# Altium schematic sheet Hub.SchDoc
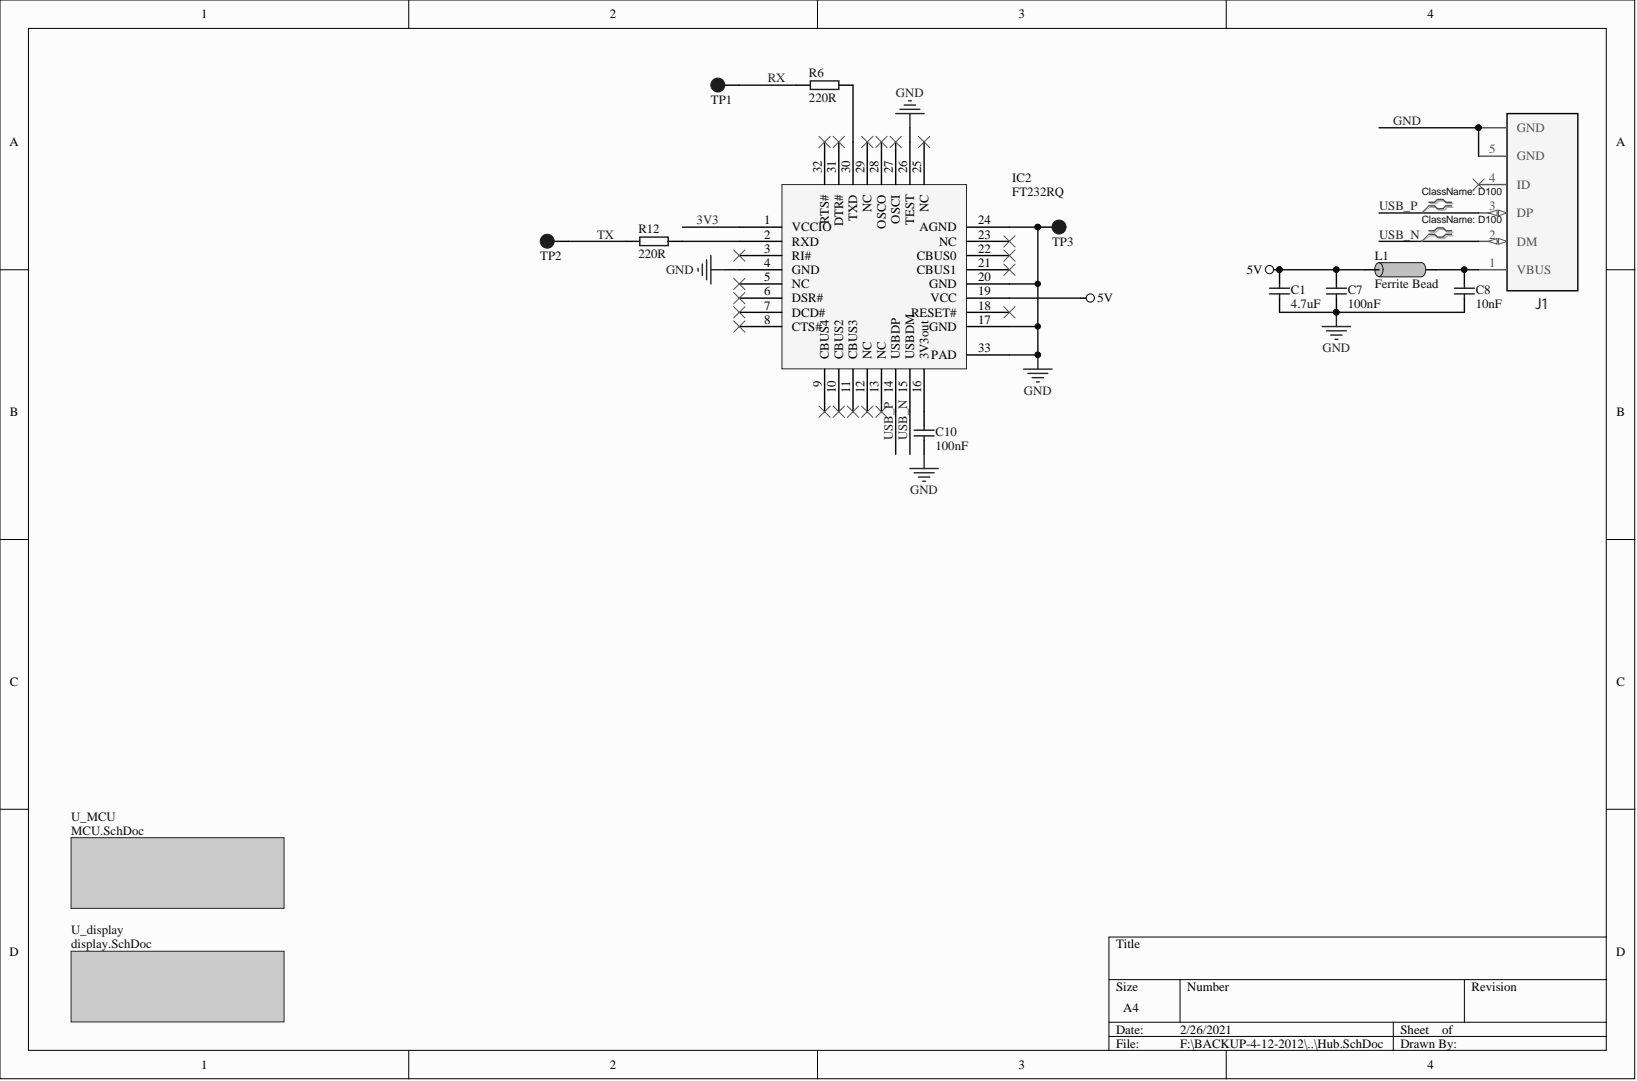
[diagram p0: Hub.SchDoc]

## Parts
C1  value 4.7uF  [CAPC1608N]  (hidden comment: CAP-NP)
C7  value 100nF  [CC0402-min-ipc]  (hidden comment: CAP-NP)
C8  value 10nF  [CC0402-min-ipc]  (hidden comment: CAP-NP)
C10  value 100nF  [CC0402-min-ipc]  (hidden comment: CAP-NP)
IC2  FT232RQ  [FT232RQ]
J1  CON_USB_micro_AB  [CON_USB_micro_AB]  (value not drawn)
L1  value Ferrite Bead  [CAPC1608N]  (hidden comment: INDUCTOR-0603)
R6  value 220R  [CC0402-min-ipc]  (hidden comment: RES)
R12  value 220R  [CC0402-min-ipc]  (hidden comment: RES)
TP1  TP  [TPSMD1mm]  (no BOM)
TP2  TP  [TPSMD1mm]  (no BOM)
TP3  TP  [TPSMD1mm]  (no BOM)

## Nets
5V: C1.1 C7.1 IC2.19(VCC) L1.1
3V3: IC2.1(VCCIO)
GND: C1.2 C7.2 C8.2 C10.2 IC2.4(GND) IC2.17(GND) IC2.20(GND) IC2.24(AGND) IC2.26(TEST) IC2.33(PAD) J1.5(GND) J1.GND TP3.1
RX: R6.1 TP1.1
TX: R12.1 TP2.1
USB_N: IC2.15(USBDM) J1.2(DM)
USB_P: IC2.14(USBDP) J1.3(DP)
NetC8_1: C8.1 J1.1(VBUS) L1.2
NetC10_1: C10.1 IC2.16(3V3out)
NetIC2_2: IC2.2(RXD) R12.2
NetIC2_3: IC2.3(RI#)
NetIC2_5: IC2.5(NC)
NetIC2_6: IC2.6(DSR#)
NetIC2_7: IC2.7(DCD#)
NetIC2_8: IC2.8(CTS#)
NetIC2_9: IC2.9(CBUS4)
NetIC2_10: IC2.10(CBUS2)
NetIC2_11: IC2.11(CBUS3)
NetIC2_12: IC2.12(NC)
NetIC2_13: IC2.13(NC)
NetIC2_18: IC2.18(RESET#)
NetIC2_21: IC2.21(CBUS1)
NetIC2_22: IC2.22(CBUS0)
NetIC2_23: IC2.23(NC)
NetIC2_25: IC2.25(NC)
NetIC2_27: IC2.27(OSCI)
NetIC2_28: IC2.28(OSCO)
NetIC2_29: IC2.29(NC)
NetIC2_30: IC2.30(TXD) R6.2
NetIC2_31: IC2.31(DTR#)
NetIC2_32: IC2.32(RTS#)
NetJ1_4: J1.4(ID)

## Library data of the parts
C1 = 4.7uF: Database Table Name: C; Component Kind: Standard; Library Name: trioflex.DbLib
C7 C8 C10 = C0402: Database Table Name: C; Component Kind: Standard; Library Name: trioflex.DbLib
IC2 = FT232RQ: Database Table Name: IC; ID: 49; Component: FT232RQ; Component Kind: Standard; Description: FT232RQ; Value: FT232RQ; Library Name: trioflex.DbLib
J1 = CON_USB_micro_AB: 5-pin Female SMT USB mini-AB (OTG) Receptacle Molex; Database Table Name: CONN; ID: 33; Component: CON_USB_micro_AB; Component Kind: Standard; Value: CON_USB_micro_AB; Library Name: trioflex.DbLib
L1 = INDUCTOR-0603: Database Table Name: MISC; ID: 9; Component: INDUCTOR-0603; Component Kind: Standard; Description: INDUCTOR-0603; Library Name: trioflex.DbLib
R6 R12 = R0402: Database Table Name: R; ID: 17; Component: R0402; Component Kind: Standard; Library Name: trioflex.DbLib
TP1-TP3 = TPSMD1mm: Testpoint; ID: 7; Component: TPSMD1mm; Database Table Name: TP; Value: TPSMD1mm; Library Name: trioflex.DbLib
